# Altium schematic sheet PRESS_Shift_Switch_TOP.SchDoc(PRESS_Shift_Switch_TOP)
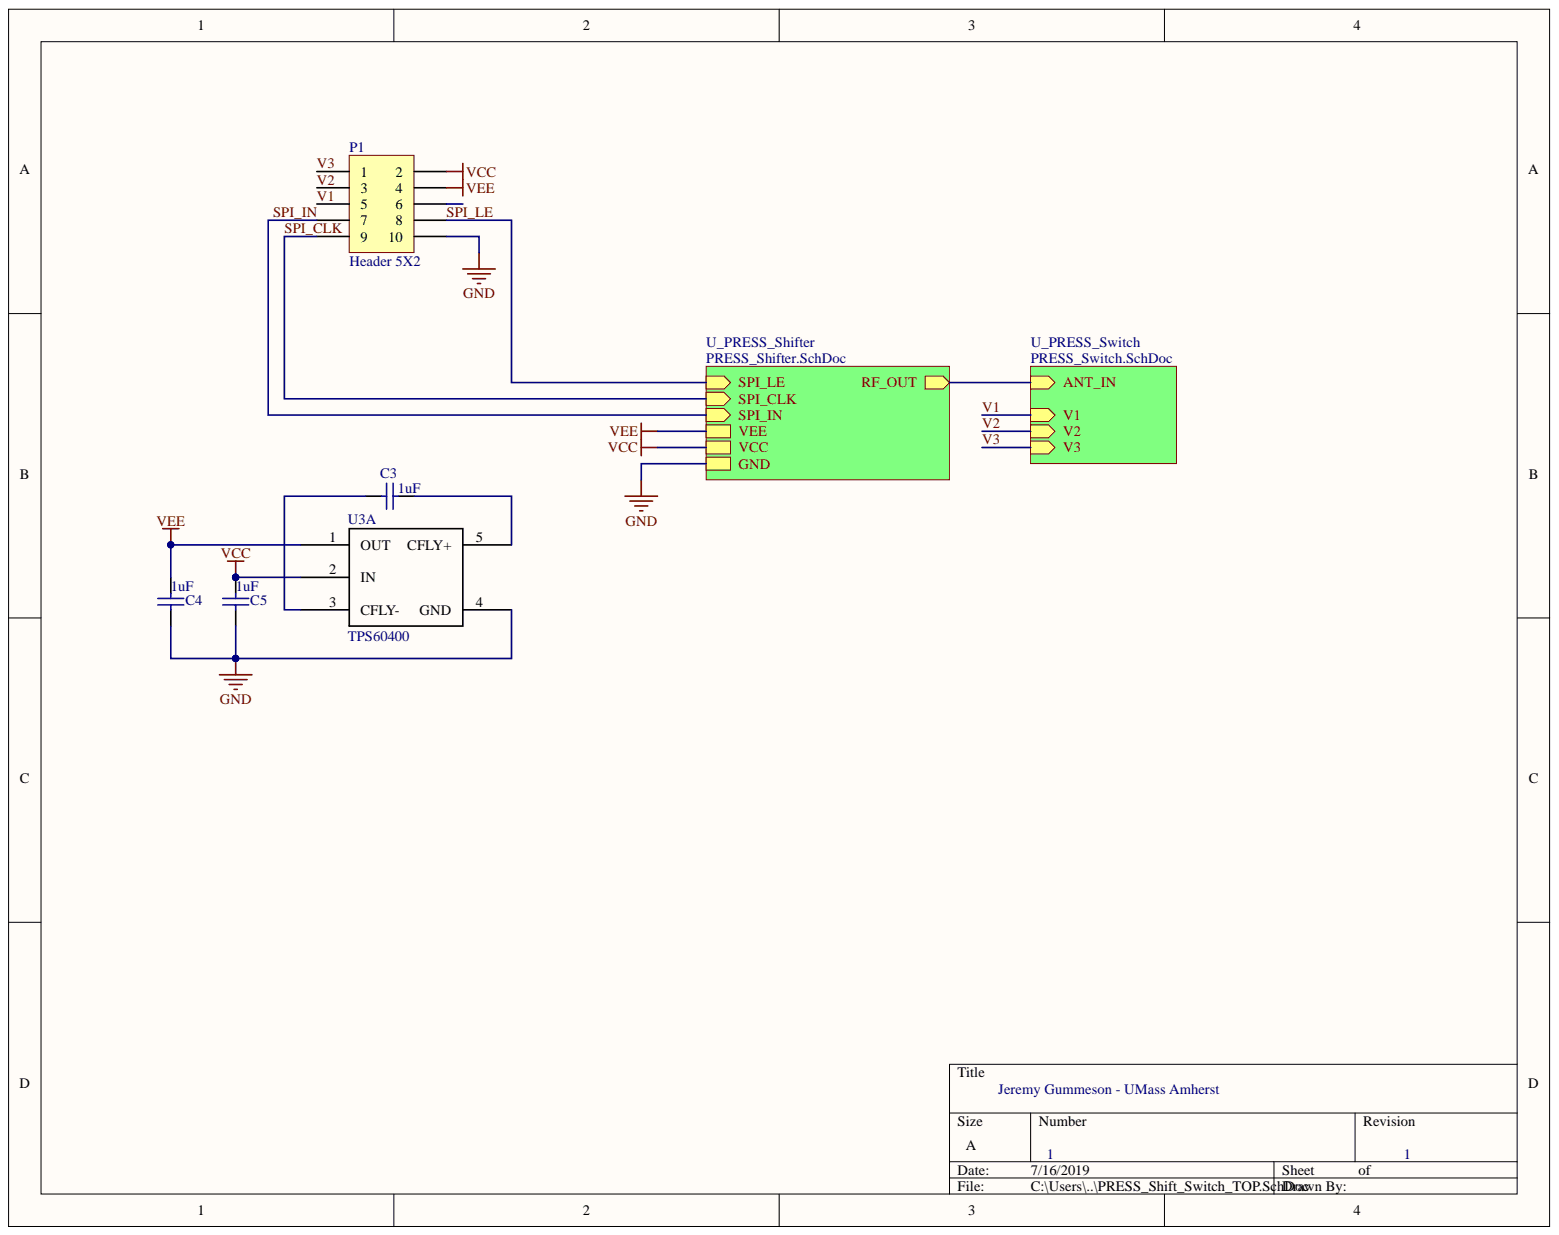
[diagram p0: PRESS_Shift_Switch_TOP.SchDoc]

## Parts
C3  value 1uF  [CAPC0603(1608)100_L]  (hidden comment: CAP0603)
C4  value 1uF  [CAPC0603(1608)100_L]  (hidden comment: CAP0603)
C5  value 1uF  [CAPC0603(1608)100_L]  (hidden comment: CAP0603)
P1  Header 5X2  [HDR2X5]
U3  TPS60400  [SOT95P280X145-5M]  (part A)

## Nets
GND: C4.1 C5.1 P1.10 U3.4(GND)
VCC: C5.2 P1.2 U3.2(IN)
VEE: C4.2 P1.4 U3.1(OUT)
SPI_CLK: P1.9
SPI_IN: P1.7
SPI_LE: P1.8
V1: P1.5
V2: P1.3
V3: P1.1
NetC3_1: C3.1 U3.3(CFLY-)
NetC3_2: C3.2 U3.5(CFLY+)
NetP1_6: P1.6
RF_OUT:

## Library data of the parts
C3-C5 = CMP-1590-00005-1: 0603 (1608 Metric) Chip Capacitor; Case-Metric: 1608; Case-EIA: 0603; PackageReference: CAPC0603(1608)100; Library Name: Altium Content Vault; Component Kind: Standard
P1 = Header 5X2: Header, 5-Pin, Dual row; Signal Integrity: Connector; Library Name: Miscellaneous Connectors.IntLib; LatestRevisionNote: Re-released for DXP Platform.; LatestRevisionDate: 17-Jul-2002; Component Kind: Standard; Publisher: Altium Limited
U3 = TPS60400: Component Kind: Standard; Library Name: PRESS_sch_components.SchLib
